# Altium schematic sheet FPGA IO Extension.Sch("FPGA IO Extension")
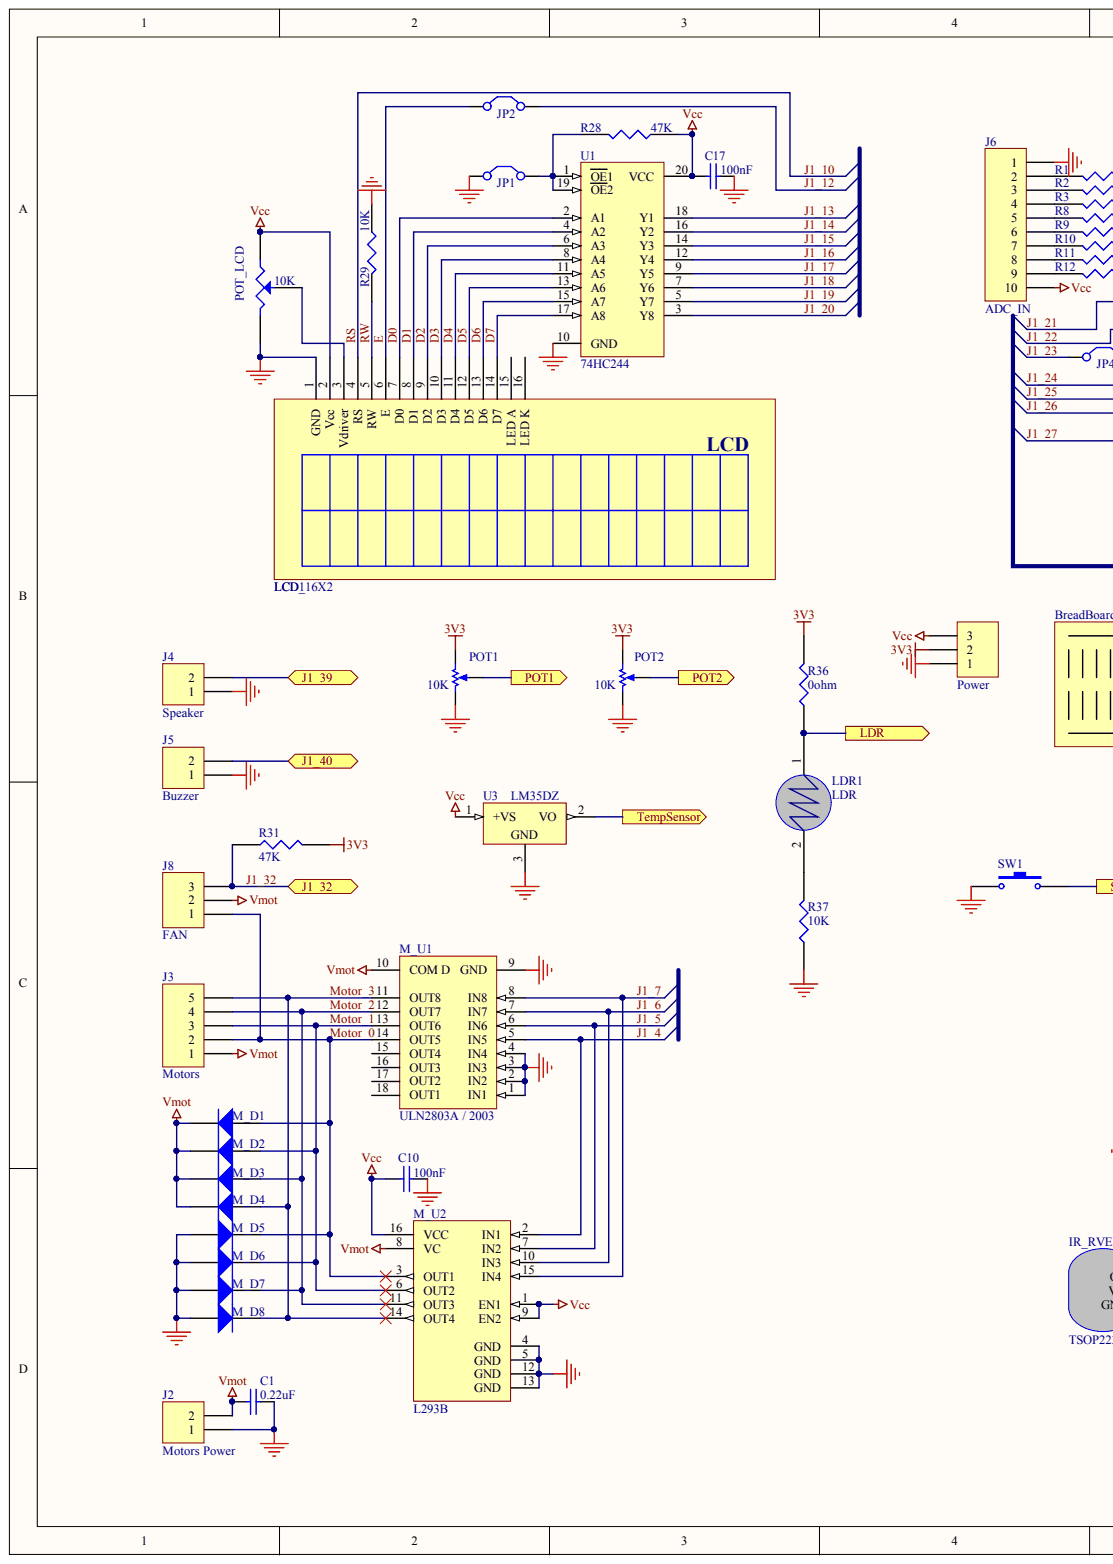
[diagram p0: FPGA IO Extension.Sch("FPGA IO Extension"), left]
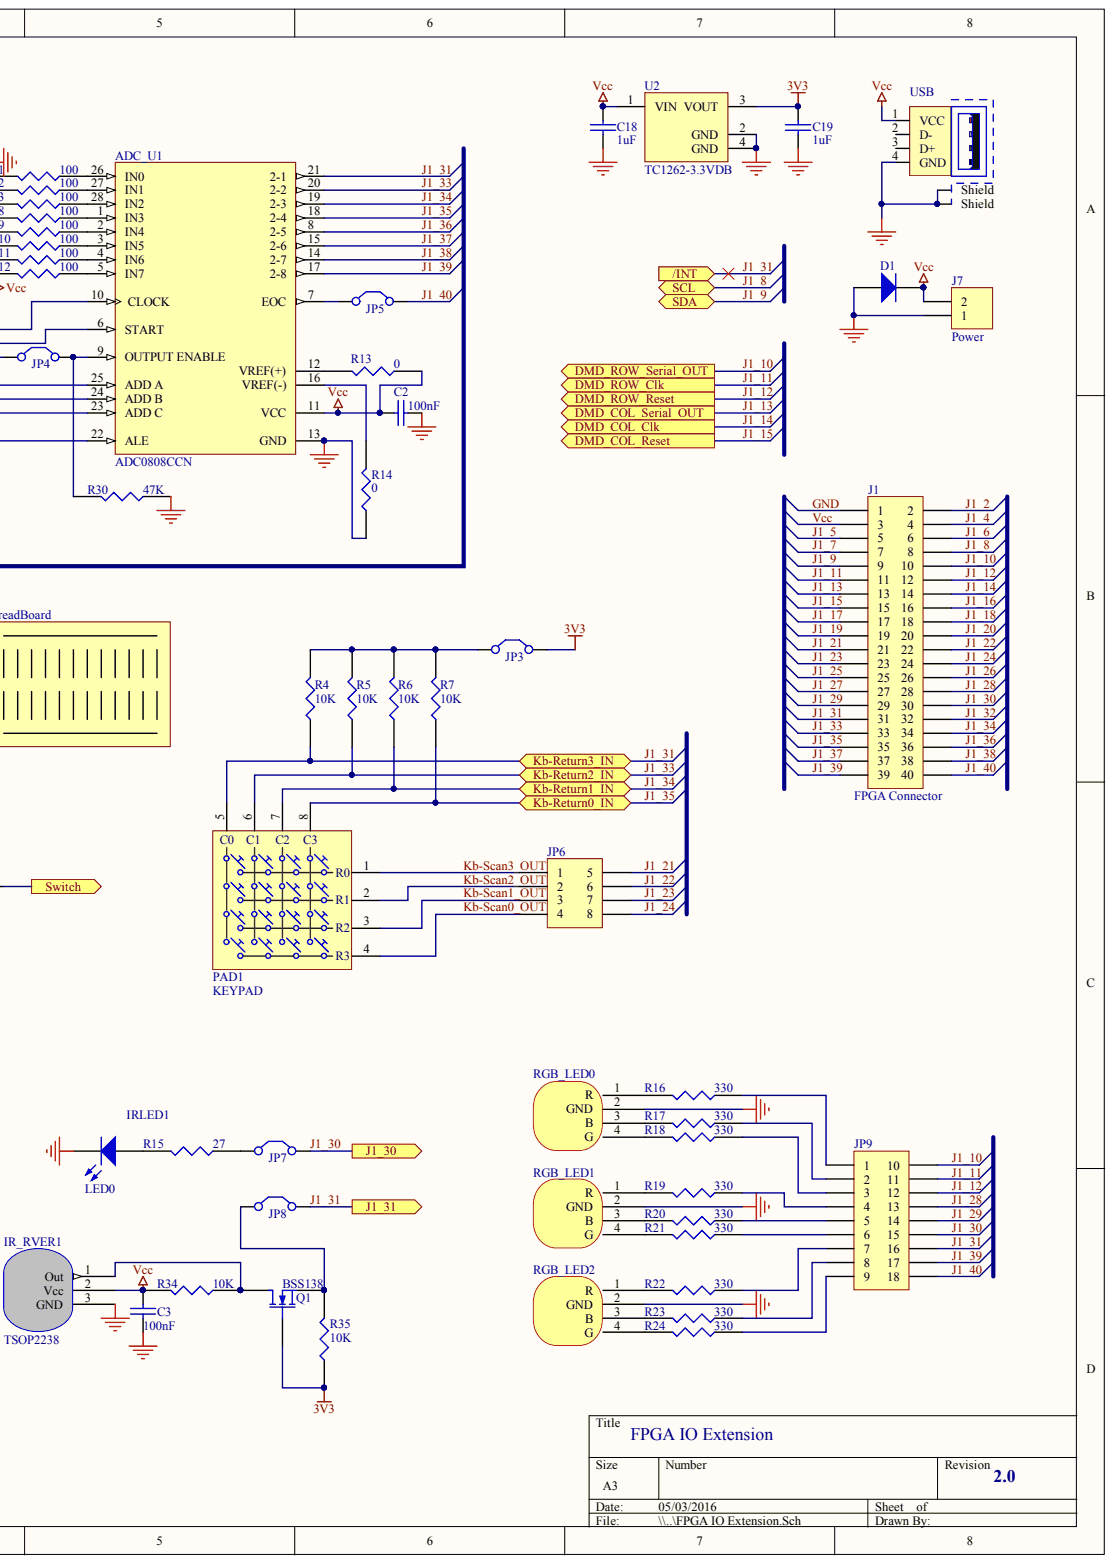
[diagram p1: FPGA IO Extension.Sch("FPGA IO Extension"), right]

## Parts
ADC_U1  ADC0808CCN  [NA28E]
BreadBoard  BreadBoard  [BreadBoard]
C1  0.22uF  [J1-0603]
C2  100nF  [J1-0603]
C3  100nF  [J1-0603]
C10  100nF  [J1-0603]
C17  100nF  [J1-0603]
C18  1uF  [SMB]
C19  1uF  [SMB]
D1  Diode 1N4003  [DIO10.46-5.3x2.8]  (value not drawn)
IR_RVER1  TSOP2238  [HDR1X3]
IRLED1  LED0  [LED-0]
J1  FPGA Connector  [HDR2X20Ext]
J2  Motors Power  [GLEMA_2_PLAC]
J3  Motors  [HDR1X5X2]
J4  Speaker  [HDR1X2]
J5  Buzzer  [HDR1X2]
J6  ADC_IN  [HDR1X10X2]
J7  Power  [GLEMA_2_PLAC]
J8  FAN  [3PIN_254]
JP1  Jumper  [HDR1X2]  (value not drawn)
JP2  Jumper  [HDR1X2]  (value not drawn)
JP3  Jumper  [HDR1X2]  (value not drawn)
JP4  Jumper  [HDR1X2]  (value not drawn)
JP5  Jumper  [HDR1X2]  (value not drawn)
JP6  Header 4X2A  [HDR2X4_CEN]  (value not drawn)
JP7  Jumper  [HDR1X2]  (value not drawn)
JP8  Jumper  [HDR1X2]  (value not drawn)
JP9  Header 9X2A  [HDR2X9_CEN]  (value not drawn)
LCD1  LCD_16X2  [LCD_DISP16x2]
LDR1  LDR  [LDR]
M_D1  Diode 1N4003  [DIO10.46-5.3x2.8]  (value not drawn)
M_D2  Diode 1N4003  [DIO10.46-5.3x2.8]  (value not drawn)
M_D3  Diode 1N4003  [DIO10.46-5.3x2.8]  (value not drawn)
M_D4  Diode 1N4003  [DIO10.46-5.3x2.8]  (value not drawn)
M_D5  Diode 1N4003  [DIO10.46-5.3x2.8]  (value not drawn)
M_D6  Diode 1N4003  [DIO10.46-5.3x2.8]  (value not drawn)
M_D7  Diode 1N4003  [DIO10.46-5.3x2.8]  (value not drawn)
M_D8  Diode 1N4003  [DIO10.46-5.3x2.8]  (value not drawn)
M_U1  ULN2803A / 2003  [A18]
M_U2  L293B  [DIP16]
PAD1  KEYPAD  [KEYPAD_16]
POT1  value 10K  [POT2]  (hidden comment: RPot)
POT2  value 10K  [POT2]  (hidden comment: RPot)
POT_LCD  10K  [pot]
Power  Power  [HDR1X3]
Q1  BSS138  [SOT23]
R1  100  [J1-0603]
R2  100  [J1-0603]
R3  100  [J1-0603]
R4  10K  [J1-0603]
R5  10K  [J1-0603]
R6  10K  [J1-0603]
R7  10K  [J1-0603]
R8  100  [J1-0603]
R9  100  [J1-0603]
R10  100  [J1-0603]
R11  100  [J1-0603]
R12  100  [J1-0603]
R13  0  [J1-0603]
R14  0  [J1-0603]
R15  27  [J1-0603]
R16  330  [J1-0603]
R17  330  [J1-0603]
R18  330  [J1-0603]
R19  330  [J1-0603]
R20  330  [J1-0603]
R21  330  [J1-0603]
R22  330  [J1-0603]
R23  330  [J1-0603]
R24  330  [J1-0603]
R28  47K  [J1-0603]
R29  10K  [J1-0603]
R30  47K  [J1-0603]
R31  47K  [J1-0603]
R34  10K  [J1-0603]
R35  10K  [J1-0603]
R36  0ohm  [J1-0603]
R37  10K  [J1-0603]
RGB_LED0  RGBLed  [rgbled]  (value not drawn)
RGB_LED1  RGBLed  [rgbled]  (value not drawn)
RGB_LED2  RGBLed  [rgbled]  (value not drawn)
SW1  Switch  [PB]  (value not drawn)
U1  74HC244  [PW020_N]
U2  TC1262-3.3VDB  [SOT-223-DB3_N]
U3  LM35DZ  [Z03A]
USB  WE-Communication Connectors 61400416121  [61400416121]  (value not drawn)

## Ports
/INT, DMD_COL_CLK, DMD_COL_RESET, DMD_COL_SERIAL_OUT, DMD_ROW_CLK, DMD_ROW_RESET, DMD_ROW_SERIAL_OUT, J1_30, J1_31, J1_32, J1_39, J1_40, KB-RETURN0_IN, KB-RETURN1_IN, KB-RETURN2_IN, KB-RETURN3_IN, LDR, POT1, POT2, SCL, SDA, SWITCH, TEMPSENSOR

## Nets
3V3: C19.2 JP3.1 POT1.1 POT2.1 Power.2 Q1.3 R31.2 R35.1 R36.2 U2.3(VOUT)
VMOT: C1.2 J2.2 J3.1 J8.2 M_D1.2 M_D2.2 M_D3.2 M_D4.2 M_U1.10(COM_D) M_U2.8(VC)
D0: LCD1.7(D0) U1.2(A1)
D1: LCD1.8(D1) U1.4(A2)
D2: LCD1.9(D2) U1.6(A3)
D3: LCD1.10(D3) U1.8(A4)
D4: LCD1.11(D4) U1.11(A5)
D5: LCD1.12(D5) U1.13(A6)
D6: LCD1.13(D6) U1.15(A7)
D7: LCD1.14(D7) U1.17(A8)
E: JP2.2 LCD1.6(E)
GND: ADC_U1.13(GND) C1.1 C2.2 C3.2 C10.1 C17.1 C18.1 C19.1 D1.1 IR_RVER1.3 IRLED1.2 J1.1 J2.1 J4.1 J5.1 J6.1 J7.1 JP1.1 LCD1.1(GND) M_D5.1 M_D6.1 M_D7.1 M_D8.1 M_U1.1(IN1) M_U1.2(IN2) M_U1.3(IN3) M_U1.4(IN4) M_U1.9(GND) M_U2.4(GND) M_U2.5(GND) M_U2.12(GND) M_U2.13(GND) POT1.2 POT2.2 POT_LCD.2 Power.1 R14.1 R29.1 R30.1 R37.1 RGB_LED0.2(GND) RGB_LED1.2(GND) RGB_LED2.2(GND) SW1.1 U1.10(GND) U2.2(GND) U2.4(GND) U3.3 USB.4(GND) USB.Z1 USB.Z2
J1_2: J1.2
J1_4: J1.4 M_U1.5(IN5) M_U2.2(IN1)
J1_5: J1.5 M_U1.6(IN6) M_U2.7(IN2)
J1_6: J1.6 M_U1.7(IN7) M_U2.10(IN3)
J1_7: J1.7 M_U1.8(IN8) M_U2.15(IN4)
J1_8: J1.8 ; port SCL
J1_9: J1.9 ; port SDA
J1_10: J1.10 JP9.10 LCD1.4(RS) ; port DMD_ROW_SERIAL_OUT
J1_11: J1.11 JP9.11 ; port DMD_ROW_CLK
J1_12: J1.12 JP2.1 JP9.12 ; port DMD_ROW_RESET
J1_13: J1.13 U1.18(Y1) ; port DMD_COL_SERIAL_OUT
J1_14: J1.14 U1.16(Y2) ; port DMD_COL_CLK
J1_15: J1.15 U1.14(Y3) ; port DMD_COL_RESET
J1_16: J1.16 U1.12(Y4)
J1_17: J1.17 U1.9(Y5)
J1_18: J1.18 U1.7(Y6)
J1_19: J1.19 U1.5(Y7)
J1_20: J1.20 U1.3(Y8)
J1_21: ADC_U1.10(CLOCK) J1.21 JP6.5
J1_22: ADC_U1.6(START) J1.22 JP6.6
J1_23: J1.23 JP4.1 JP6.7
J1_24: ADC_U1.25(ADD_A) J1.24 JP6.8
J1_25: ADC_U1.24(ADD_B) J1.25
J1_26: ADC_U1.23(ADD_C) J1.26
J1_27: ADC_U1.22(ALE) J1.27
J1_28: J1.28 JP9.13
J1_29: J1.29 JP9.14
J1_30: J1.30 JP7.1 JP9.15 ; port J1_30
J1_31: ADC_U1.21(2-1) J1.31 JP8.1 JP9.16 PAD1.5(C0) R4.1 ; port /INT, port J1_31
J1_32: J1.32 J8.3 R31.1 ; port J1_32
J1_33: ADC_U1.20(2-2) J1.33 PAD1.6(C1) R5.1 ; port KB-RETURN2_IN
J1_34: ADC_U1.19(2-3) J1.34 PAD1.7(C2) R6.1 ; port KB-RETURN1_IN
J1_35: ADC_U1.18(2-4) J1.35 PAD1.8(C3) R7.1 ; port KB-RETURN0_IN
J1_36: ADC_U1.8(2-5) J1.36
J1_37: ADC_U1.15(2-6) J1.37
J1_38: ADC_U1.14(2-7) J1.38
J1_39: ADC_U1.17(2-8) J1.39 JP9.17
J1_40: J1.40 JP5.1 JP9.18
KB-SCAN0_OUT: JP6.4 PAD1.4(R3)
KB-SCAN1_OUT: JP6.3 PAD1.3(R2)
KB-SCAN2_OUT: JP6.2 PAD1.2(R1)
KB-SCAN3_OUT: JP6.1 PAD1.1(R0)
LDR: LDR1.1 R36.1 ; port LDR
MOTOR_0: J3.2 J8.1 M_D1.1 M_D5.2 M_U1.14(OUT5) M_U2.3(OUT1)
MOTOR_1: J3.3 M_D2.1 M_D6.2 M_U1.13(OUT6) M_U2.6(OUT2)
MOTOR_2: J3.4 M_D3.1 M_D7.2 M_U1.12(OUT7) M_U2.11(OUT3)
MOTOR_3: J3.5 M_D4.1 M_D8.2 M_U1.11(OUT8) M_U2.14(OUT4)
POT1: POT1.3 ; port POT1
POT2: POT2.3 ; port POT2
RW: LCD1.5(RW) R29.2
VCC: ADC_U1.11(VCC) C2.1 C3.1 C10.2 C17.2 C18.2 D1.2 IR_RVER1.2 J1.3 J6.10 J7.2 LCD1.2(Vcc) M_U2.1(EN1) M_U2.9(EN2) M_U2.16(VCC) POT_LCD.1 Power.3 R13.1 R28.1 R34.2 U1.20(VCC) U2.1(VIN) U3.1 USB.1(VCC)
NetADC_U1_1: ADC_U1.1(IN3) R8.1
NetADC_U1_2: ADC_U1.2(IN4) R9.1
NetADC_U1_3: ADC_U1.3(IN5) R10.1
NetADC_U1_4: ADC_U1.4(IN6) R11.1
NetADC_U1_5: ADC_U1.5(IN7) R12.1
NetADC_U1_7: ADC_U1.7(EOC) JP5.2
NetADC_U1_9: ADC_U1.9(OUTPUT_ENABLE) JP4.2 R30.2
NetADC_U1_12: ADC_U1.12(VREF(+)) R13.2
NetADC_U1_16: ADC_U1.16(VREF(-)) R14.2
NetADC_U1_26: ADC_U1.26(IN0) R1.1
NetADC_U1_27: ADC_U1.27(IN1) R2.1
NetADC_U1_28: ADC_U1.28(IN2) R3.1
NetIR_RVER1_1: IR_RVER1.1 Q1.1 R34.1
NetIRLED1_1: IRLED1.1 R15.2
NetJ4_2: J4.2 ; port J1_39
NetJ5_2: J5.2 ; port J1_40
NetJ6_2: J6.2 R1.2
NetJ6_3: J6.3 R2.2
NetJ6_4: J6.4 R3.2
NetJ6_5: J6.5 R8.2
NetJ6_6: J6.6 R9.2
NetJ6_7: J6.7 R10.2
NetJ6_8: J6.8 R11.2
NetJ6_9: J6.9 R12.2
NetJP1_2: JP1.2 R28.2 U1.1(OE1) U1.19(OE2)
NetJP3_2: JP3.2 R4.2 R5.2 R6.2 R7.2
NetJP7_2: JP7.2 R15.1
NetJP8_2: JP8.2 Q1.2 R35.2
NetJP9_1: JP9.1 R16.1
NetJP9_2: JP9.2 R17.1
NetJP9_3: JP9.3 R18.1
NetJP9_4: JP9.4 R19.1
NetJP9_5: JP9.5 R20.1
NetJP9_6: JP9.6 R21.1
NetJP9_7: JP9.7 R22.1
NetJP9_8: JP9.8 R23.1
NetJP9_9: JP9.9 R24.1
NetLCD1_3: LCD1.3(Vdriver) POT_LCD.3
NetLDR1_2: LDR1.2 R37.2
NetR16_2: R16.2 RGB_LED0.1(R)
NetR17_2: R17.2 RGB_LED0.3(B)
NetR18_2: R18.2 RGB_LED0.4(G)
NetR19_2: R19.2 RGB_LED1.1(R)
NetR20_2: R20.2 RGB_LED1.3(B)
NetR21_2: R21.2 RGB_LED1.4(G)
NetR22_2: R22.2 RGB_LED2.1(R)
NetR23_2: R23.2 RGB_LED2.3(B)
NetR24_2: R24.2 RGB_LED2.4(G)
NetSW1_2: SW1.2 ; port SWITCH
NetU3_2: U3.2 ; port TEMPSENSOR
N00169:
N00170:
N00171:
N00172:
N00173:
N00174:
N00175:
N00176:
N00177:

Not connected: LCD1 15(LED_A) 16(LED_K); M_U1 15(OUT4) 16(OUT3) 17(OUT2) 18(OUT1); USB 2(D-) 3(D+)

## Library data of the parts
ADC_U1 = ADC0808CCN: 8-bit Microprocessor Compatible A/D Converters With 8-Channel Multiplexer; Manufacturer: National Semiconductor; CompType: ADC IC; PackageTopMarking: NSUZXYYTTE#*ADC0808CCN; PowerWise: N; Component Kind: Standard; ComponentLink1Description: ADC0808 Datasheet; ComponentLink1URL: http://www.national.com/ds/AD/ADC0808.pdf; ComponentLink2Description: ADC0808 Web Page; ComponentLink2URL: http://www.national.com/pf/AD/ADC0808.html; ComponentLink3Description: NA28E Package Page; ComponentLink3URL: http://www.national.com/packaging/folders/na28e.html; DatasheetVersion: Sep-1992; DigiKeyPartNumber: ADC0808CCN-ND; Library Name: NSC ADC.IntLib; PackageDescription: Molded Dip, 28 Lead, .600 Centers, Substractor Package; PackageReference: NA28E; PackageVersion: revB, Aug-1993; PartNumber: ADC0808CCN; PinCount: 28; Published: 4-Dec-2008; RoHS: O; Publisher: Altium Limited
C1-C3 C10 C17-C19 = CAP: Capacitor; Component Kind: Standard
D1 M_D1-M_D8 = Diode 1N4003: 1 Amp General Purpose Rectifier; Code_JEDEC: DO-204-AL; Component Kind: Standard; ComponentLink1Description: Manufacturer Link; ComponentLink1URL: http://www.fairchildsemi.com/; ComponentLink2Description: Datasheet; ComponentLink2URL: http://www.fairchildsemi.com/ds/1N%2F1N4001.pdf; DatasheetVersion: 1998; LatestRevisionDate: 17-Jul-2002; LatestRevisionNote: Re-released for DXP Platform.; Library Name: Miscellaneous Devices.IntLib; PackageReference: DO-41; PackageVersion: Aug-1999; Published: 8-Jun-2000; Signal Integrity: 1N4003; Simulation: 1N4003; Publisher: Altium Limited
IRLED1 = LED0: Typical INFRARED GaAs LED; Component Kind: Standard; LatestRevisionDate: 08-Jul-2005; LatestRevisionNote: Mech Layer 1 removed.; Library Name: Miscellaneous Devices.IntLib; PackageReference: LED-0; Published: 8-Jun-2000; Simulation: LED0; Publisher: Altium Limited
J1 = Header 20X2: Header, 20-Pin, Dual row; Component Kind: Standard; LatestRevisionDate: 17-Jul-2002; LatestRevisionNote: Re-released for DXP Platform.; Library Name: Miscellaneous Connectors.IntLib; Signal Integrity: Connector; Publisher: Altium Limited
J2 J4 J5 J7 = Header 2: Header, 2-Pin; Component Kind: Standard; LatestRevisionDate: 17-Jul-2002; LatestRevisionNote: Re-released for DXP Platform.; Library Name: Miscellaneous Connectors.IntLib; Signal Integrity: Connector; Publisher: Altium Limited
J3 = Header 5: Header, 5-Pin; Component Kind: Standard; LatestRevisionDate: 17-Jul-2002; LatestRevisionNote: Re-released for DXP Platform.; Library Name: Miscellaneous Connectors.IntLib; Signal Integrity: Connector; Publisher: Altium Limited
J6 = Header 10: Header, 10-Pin; Component Kind: Standard; LatestRevisionDate: 17-Jul-2002; LatestRevisionNote: Re-released for DXP Platform.; Library Name: Miscellaneous Connectors.IntLib; Signal Integrity: Connector; Publisher: Altium Limited
J8 Power = Header 3: Header, 3-Pin Molex 22-27-2031; RS: 483-8477; Component Kind: Standard; LatestRevisionDate: 17-Jul-2002; LatestRevisionNote: Re-released for DXP Platform.; Library Name: Miscellaneous Connectors.IntLib; Signal Integrity: Connector; Publisher: Altium Limited
JP1-JP5 JP7 JP8 = Jumper: Jumper Wire; Component Kind: Standard; LatestRevisionDate: 17-Jul-2002; LatestRevisionNote: Re-released for DXP Platform.; Library Name: Miscellaneous Devices.IntLib; PackageReference: RAD-0.2; Published: 24-Mar-1999; Publisher: Altium Limited
JP6 = Header 4X2A: Header, 4-Pin, Dual row; Component Kind: Standard; LatestRevisionDate: 17-Jul-2002; LatestRevisionNote: Re-released for DXP Platform.; Library Name: Miscellaneous Connectors.IntLib; Signal Integrity: Connector; Publisher: Altium Limited
JP9 = Header 9X2A: Header, 9-Pin, Dual row; Component Kind: Standard; LatestRevisionDate: 17-Jul-2002; LatestRevisionNote: Re-released for DXP Platform.; Library Name: Miscellaneous Connectors.IntLib; Signal Integrity: Connector; Publisher: Altium Limited
M_U1 = ULN2803A: High-Voltage, High-Current Darlington Array; Component Kind: Standard; ComponentLink1Description: Manufacturer Link; ComponentLink1URL: http://www.allegromicro.com/; DatasheetVersion: 1999; LatestRevisionDate: 06-Jun-2005; LatestRevisionNote: Stylized 3D Model Added.; PackageDescription: DIP; 18 Leads; Row Spacing 7.62 mm; Pitch 2.54 mm; PackageReference: A18; PackageVersion: 1999; PCB3D: A18; Published: 13-Oct-2000; Library Name: Allegro Interface Darlington Driver.IntLib; Publisher: Altium Limited
M_U2 = L293B: Push-Pull Four Channel Drivers; Component Kind: Standard; ComponentLink1Description: Manufacturer Link; ComponentLink1URL: http://www.st.com/; ComponentLink2Description: Datasheet; ComponentLink2URL: http://www.st.com/stonline/products/literature/ds/1328/l293b.pdf; DatasheetVersion: Apr-1993; LatestRevisionDate: 06-Jun-2005; LatestRevisionNote: Stylized 3D Model Added.; Library Name: ST Interface DC & Stepper Motor Controller.IntLib; PackageDescription: DIP; 16 Leads; Row Spacing 7.62 mm; Pitch 2.54 mm; PackageReference: DIP16; PackageVersion: Apr-1996; PCB3D: DIP16; Published: 8-Jun-2000; Publisher: Altium Limited
PAD1 = KEYPAD_16: Component Kind: Standard
POT1 POT2 = RPot: Potentiometer; Set Position: 0.5; Sim Note: Enter the Resistance value and the set point into parameter fields.; Component Kind: Standard; LatestRevisionDate: 17-Jul-2002; LatestRevisionNote: Re-released for DXP Platform.; Library Name: Miscellaneous Devices.IntLib; PackageDescription: Variable Resistor, Thru-Hole; 3 Leads; PackageReference: VR5; Published: 8-Jun-2000; Publisher: Altium Limited
POT_LCD = POT1: Potentiometer; Component Kind: Standard
Q1 = BSS138: N-Channel Enhancement Mode Vertical DMOS FET; Component Kind: Standard; ComponentLink1Description: Manufacturer Link; ComponentLink1URL: http://www.zetex.com/; ComponentLink2Description: Datasheet; ComponentLink2URL: www.zetex.com/3.0/pdf/BSS138.pdf; DatasheetVersion: Mar-96; Library Name: Zetex Discrete MOSFET.IntLib; PackageDescription: SOT-23; 3 Leads; Body 3.1 x 2.5 mm, inc. leads (LxW max), Alternate Lead Configuration; PackageReference: SOT23; PackageVersion: 1996; Published: 17-Dec-2003; Publisher: Altium Limited
R1-R24 R28-R31 R34-R37 = RES1: Component Kind: Standard
SW1 = SW-PB: Component Kind: Standard; Description: Switch; LatestRevisionDate: 17-Jul-2002; LatestRevisionNote: Re-released for DXP Platform.; Library Name: Miscellaneous Devices.IntLib; PackageDescription: Switch; 2 Leads; PackageReference: SPST-2; Published: 24-Mar-1999; Publisher: Altium Limited
U1 = SN74HC244PWLE: Octal Buffer and Line Driver with 3-State Outputs; ComponentAvailability: Obsolete; Component Kind: Standard; ComponentLink1Description: Manufacturer Link; ComponentLink1URL: http://www.ti.com/; ComponentLink2Description: Datasheet; ComponentLink2URL: http://www-s.ti.com/sc/ds/sn74hc244.pdf; DatasheetVersion: May-1997; LatestRevisionDate: 16-Oct-2006; LatestRevisionNote: IPC-7351 Footprint Added.; Library Name: TI Logic Buffer Line Driver.IntLib; PackageDescription: 20-Pin Thin Small Outline Package 0.65 mm Pitch; PackageReference: PW020; PackageVersion: Feb-1999; PCB3D: PW020; Published: 8-Jun-2000; Signal Integrity: SN74HC244PWLE; Publisher: Altium Limited
U2 = TC1262-3.3VDB: 500mA Fixed Output CMOS LDO, 3-Pin SOT-223, Extended Temperature; Component Kind: Standard; ComponentLink1Description: Datasheet; ComponentLink1URL: http://ww1.microchip.com/downloads/en/DeviceDoc/21373b.pdf; ComponentLink2Description: Packaging Specification; ComponentLink2URL: http://www.microchip.com/stellent/groups/techpub_sg/documents/packagingspec/en012702.pdf; ComponentLink3Description: Microchip.com Home; ComponentLink3URL: http://www.microchip.com/; DatasheetVersion: revB, 2002; Library Name: Microchip Power Mgt Line Regulator.IntLib; PackageDescription: 3-Lead Plastic Small Outline Transistor (DB) [SOT-223]; PackageReference: SOT-223-DB3; PackageVersion: revBB, Aug-2009; Published: 29-Jan-2009; Supplier 1: Digi-Key; Supplier Part Number 1: TC1262-3.3VDB-ND; Publisher: Altium Limited
U3 = LM35DZ: Precision Centigrade Temperature Sensor, 3-pin TO-92; Manufacturer: National Semiconductor; CompType: Temp Sensor IC; PackageTopMarking: NSXYTT*LM35*DZ; PowerWise: N; Code_JEDEC: TO-226-AA; Component Kind: Standard; ComponentLink1Description: LM35 Datasheet; ComponentLink1URL: http://www.national.com/ds/LM/LM35.pdf; ComponentLink2Description: LM35 Web Page; ComponentLink2URL: http://www.national.com/pf/LM/LM35.html; ComponentLink3Description: Z03A Package Page; ComponentLink3URL: http://www.national.com/packaging/folders/z03a.html; DatasheetVersion: Nov-2000; LatestRevisionDate: 15-Jul-2009; LatestRevisionNote: Sch pins 1 & 3 reversed.; Library Name: NSC Temperature Sensor.IntLib; PackageDescription: TO-92, Molded, 3 Lead; PackageReference: Z03A; PackageVersion: revG, Sep-2000; PartNumber: LM35DZ; PinCount: 3; Published: 4-Dec-2008; RoHS: N; Supplier 1: Farnell; Supplier Part Number 1: 9488200; Publisher: Altium Limited
USB = WE-Communication Connectors 61400416121: WR-COM Horizontal USB Type B; Manufacturer: Würth Elektronik eiSos GmbH; ComponentLink1 Description: Manufacturer; ComponentLink2 Description: Datasheet; NoPins: 4; Component Kind: Standard; ComponentLink1URL: http://www.we-online.de; ComponentLink2URL: http://katalog.we-online.de/em/datasheet/61400416121.pdf; Created Date: 10/10/2014; Library Name: WE_WR-COM_Communication Connectors_rev15d.IntLib; partNo: 61400416121; Created by: Karrer Zheng
BreadBoard = BreadBoard: Component Kind: Standard; Library Name: Schlib1.SchLib
IR_RVER1 = TSOP2238: Component Kind: Standard; Library Name: FPGA IO Extension.IntLib
LCD1 = LCD_16X2: Component Kind: Standard
LDR1 = LDR: Component Kind: Standard; Library Name: Schlib1.SchLib
RGB_LED0-RGB_LED2 = RGBLed: Component Kind: Standard; Library Name: FPGA IO Extension.IntLib
